# Altium schematic sheet IC.SchDoc
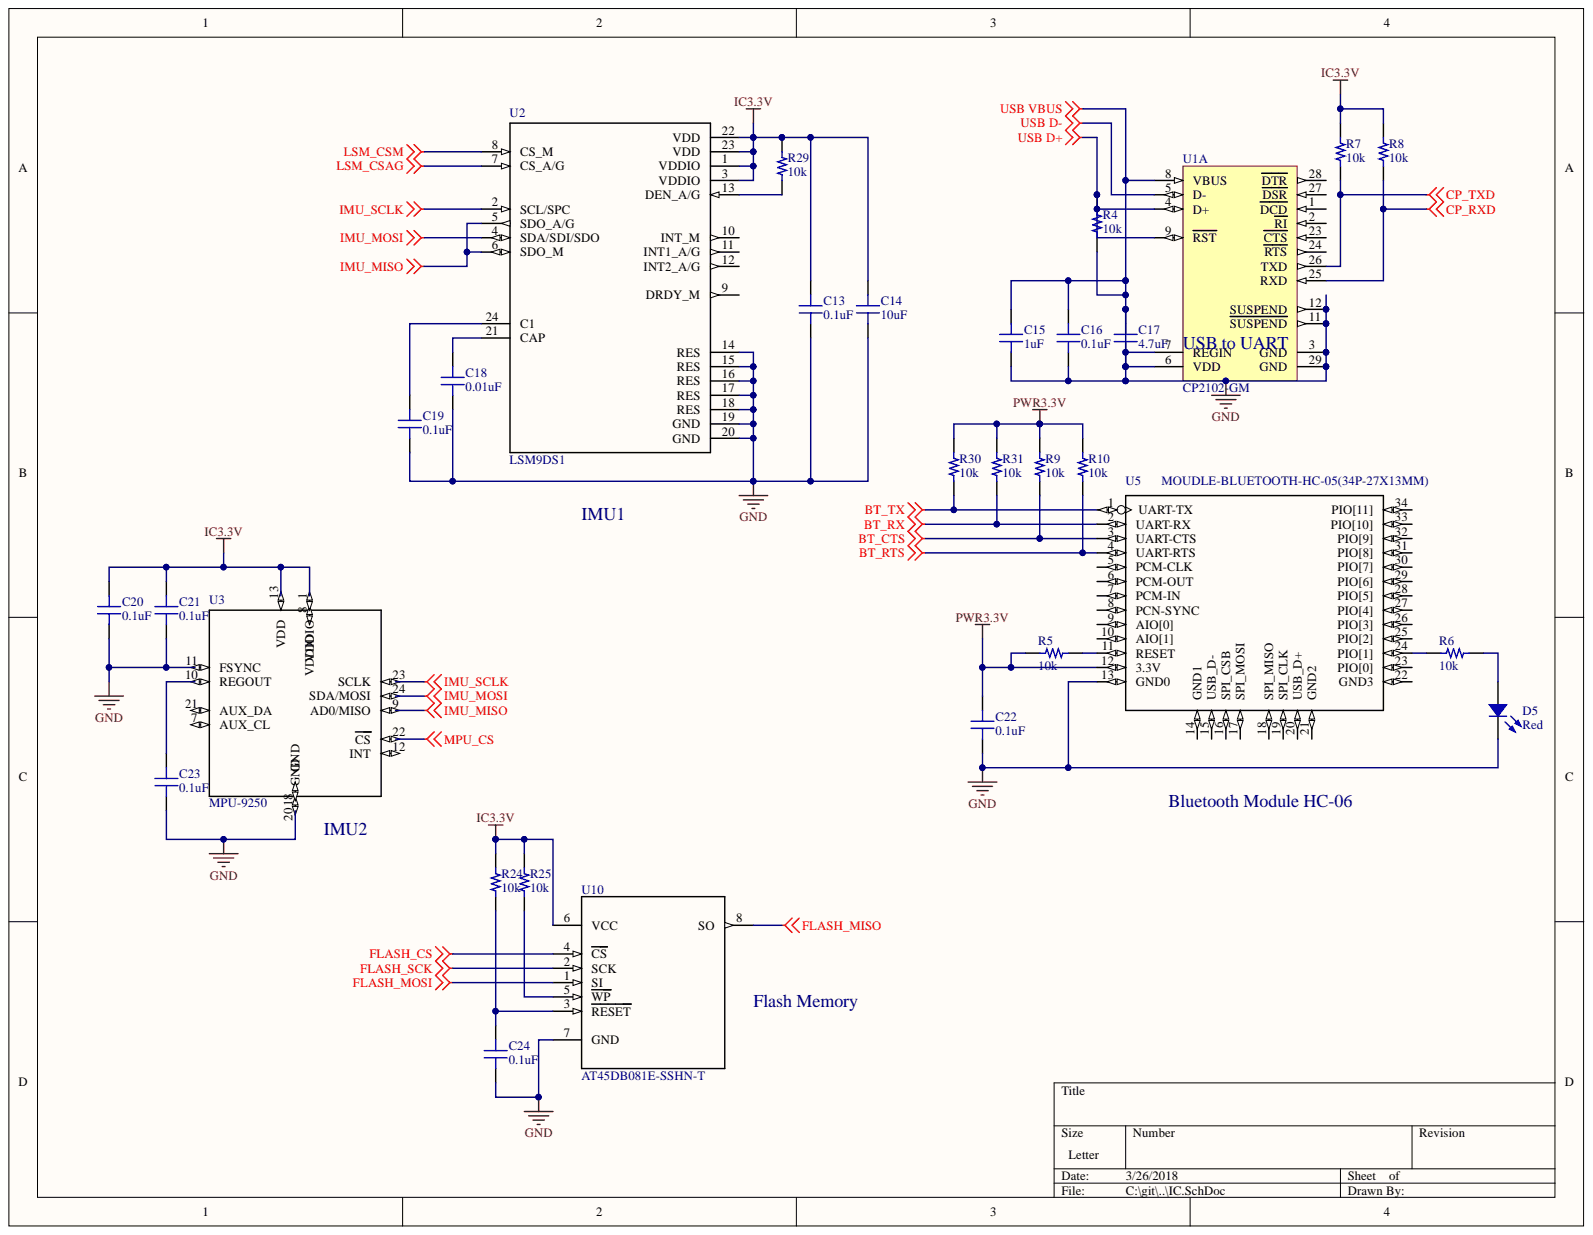
[diagram p0: IC.SchDoc]

## Parts
C13  0.1uF  [CAP 0603/1608]
C14  10uF  [CAP 0603/1608]
C15  1uF  [CAP 0603/1608]
C16  0.1uF  [CAP 0603/1608]
C17  4.7uF  [CAP 0603/1608]
C18  0.01uF  [CAP 0603/1608]
C19  0.1uF  [CAP 0603/1608]
C20  0.1uF  [CAP 0603/1608]
C21  0.1uF  [CAP 0603/1608]
C22  0.1uF  [CAP 0603/1608]
C23  0.1uF  [CAP 0603/1608]
C24  0.1uF  [CAP 0603/1608]
D5  Red  [LED 0603/1608 RED]
R4  10k  [RES 0603/1608]
R5  10k  [RES 0603/1608]
R6  10k  [RES 0603/1608]
R7  10k  [RES 0603/1608]
R8  10k  [RES 0603/1608]
R9  10k  [RES 0603/1608]
R10  10k  [RES 0603/1608]
R24  10k  [RES 0603/1608]
R25  10k  [RES 0603/1608]
R29  10k  [RES 0603/1608]
R30  10k  [RES 0603/1608]
R31  10k  [RES 0603/1608]
U1  CP2102-GM  [SLAB-QFN-28-3125x3125TP_N]  (part A)
U2  LSM9DS1  [PQFN24P43_350X300X102L35X23N]
U3  MPU-9250  [QFN-24/3MM]
U5  MOUDLE-BLUETOOTH-HC-05(34P-27X13MM)  [BT34P-SMD-1.5-26.9X13.0X2.2MM]
U10  AT45DB081E-SSHN-T  [SOIC127P600X175-8N]

## Nets
BT_CTS: R9.1 U5.3(UART-CTS)
BT_RTS: R10.1 U5.4(UART-RTS)
BT_RX: R31.1 U5.2(UART-RX)
BT_TX: R30.1 U5.1(UART-TX)
CP_RXD: R8.1 U1.25(RXD)
CP_TXD: R7.1 U1.26(TXD)
FLASH_CS: U10.4(CS)
FLASH_MISO: U10.8(SO)
FLASH_MOSI: U10.1(SI)
FLASH_SCK: U10.2(SCK)
IC3.3V: C13.1 C14.1 C20.1 C21.1 R7.2 R8.2 R24.2 R25.2 R29.2 U2.1(VDDIO) U2.3(VDDIO) U2.22(VDD) U2.23(VDD) U3.1(VDDIO) U3.13(VDD) U10.6(VCC)
IMU_MISO: U2.5(SDO_A/G) U2.6(SDO_M) U3.9(AD0/MISO)
IMU_MOSI: U2.4(SDA/SDI/SDO) U3.24(SDA/MOSI)
IMU_SCLK: U2.2(SCL/SPC) U3.23(SCLK)
LSM_CSAG: U2.7(CS_A/G)
LSM_CSM: U2.8(CS_M)
MPU_CS: U3.22(CS)
PWR3.3V: C22.1 R5.1 R9.2 R10.2 R30.2 R31.2 U5.12(3.3V)
USB D+: R4.2 U1.4(D+) U1.9(RST)
USB D-: U1.5(D-)
USB VBUS: C13.2 C14.2 C15.1 C15.2 C16.1 C16.2 C17.1 C17.2 C18.2 C19.2 C20.2 C21.2 C22.2 C23.2 C24.2 D5.2 R4.1 U1.3(GND) U1.6(VDD) U1.7(REGIN) U1.8(VBUS) U1.11(SUSPEND) U1.12(SUSPEND) U1.29(GND) U2.14(RES) U2.15(RES) U2.16(RES) U2.17(RES) U2.18(RES) U2.19(GND) U2.20(GND) U3.11(FSYNC) U3.20(GND) U5.13(GND0) U10.7(GND)
NetC18_1: C18.1 U2.21(CAP)
NetC19_1: C19.1 U2.24(C1)
NetC23_1: C23.1 U3.10(REGOUT)
NetC24_1: C24.1 R24.1 U10.3(RESET)
NetD5_1: D5.1 R6.2
NetR5_2: R5.2 U5.11(RESET)
NetR6_1: R6.1 U5.24(PIO[1])
NetR25_1: R25.1 U10.5(WP)
NetR29_1: R29.1 U2.13(DEN_A/G)

Not connected: U1 1(DCD) 2(RI) 23(CTS) 24(RTS) 27(DSR) 28(DTR); U2 9(DRDY_M) 10(INT_M) 11(INT1_A/G) 12(INT2_A/G); U3 7(AUX_CL) 8(VDDIO) 12(INT) 18(GND) 21(AUX_DA); U5 5(PCM-CLK) 6(PCM-OUT) 7(PCM-IN) 8(PCN-SYNC) 9(AIO[0]) 10(AIO[1]) 14(GND1) 15(USB_D-) 16(SPI_CSB) 17(SPI_MOSI) 18(SPI_MISO) 19(SPI_CLK) 20(USB_D+) 21(GND2) 22(GND3) 23(PIO[0]) 25(PIO[2]) 26(PIO[3]) 27(PIO[4]) 28(PIO[5]) 29(PIO[6]) 30(PIO[7]) 31(PIO[8]) 32(PIO[9]) 33(PIO[10]) 34(PIO[11])

## Library data of the parts
C13-C24 = C1608X7R1E104K080AA: CAP CER 0.1UF 25V X7R 0603; Manufacturer: TDK Corporation; Database Table Name: Capacitor - Ceramic; TemperatureRange: -55C ~ 125C; Tolerance: 10%; Component Kind: Standard; ComponentLink1Description: Datasheet; ComponentLink1URL: https://product.tdk.com/info/en/catalog/datasheets/mlcc_commercial_general_en.pdf; ComponentLink2Description: Digi-Key listing; ComponentLink2URL: http://digikey.com/product-detail/en/C1608X7R1E104K080AA/445-1316-1-ND/567697; Device Package: 0603 (1608 Metric); Library Name: Celestial Library Database.DbLib; PartId: 4029; Part Number: C1608X7R1E104K080AA; Price: 0.14; Supplier 1: Digi-Key; Supplier Part Number 1: 445-1316-1-ND; TemperatureCoefficient: X7R; Type: -; Voltage: 25V
  C13 C16 C19-C24: Value: 0.1uF
  C14: Value: 10uF
  C15: Value: 1uF
  C17: Value: 4.7uF
  C18: Value: 0.01uF
D5 = LTST-C191KRKT: LED RED CLEAR 0603 SMD; Manufacturer: Lite-On Inc.; Current (Test): 20mA; Database Table Name: Chip LED; Millicandela: 54mcd; Value: Green; Viewing Angle: 130; Voltage Forward: 2V; Colour: Red; Component Kind: Standard; ComponentLink1Description: Datasheet; ComponentLink1URL: http://optoelectronics.liteon.com/upload/download/DS22-2000-223/S_110_LTST-C191KRKT.pdf; ComponentLink2Description: Digi-Key Listing; ComponentLink2URL: http://www.digikey.ca/product-detail/en/LTST-C191KRKT/160-1447-2-ND/386837; Device Package: 0603 (1608 Metric); Lens Transparency: Clear; Library Name: Celestial Library Database.DbLib; PartId: 7050; Part Number: LTST-C191KRKT; Price: 0.0521; Supplier 1: Digi-Key; Supplier Part Number 1: 160-1447-2-ND
R4-R10 R24 R25 R29-R31 = ERJ-3EKF1002V: RES SMD 10K OHM 1% 1/10W 0603; Manufacturer: Panasonic Electronic Components; Database Table Name: Resistor - Chip; PowerRating: 0.1W, 1/10W; TemperatureCoefficient: 100ppm/C; TemperatureRange: -55C ~ 155C; Component Kind: Standard; ComponentLink1Description: Datasheet; ComponentLink1URL: http://industrial.panasonic.com/www-cgi/jvcr13pz.cgi?E+PZ+3+AOA0002+ERJ3EKF1002V+7+WW; ComponentLink2Description: Digi-Key listing; ComponentLink2URL: http://digikey.com/product-detail/en/ERJ-3EKF1002V/P10.0KHTR-ND/196066; Device Package: 0603 (1608 Metric); Library Name: Celestial Library Database.DbLib; PartId: 886; Part Number: ERJ-3EKF1002V; Price: 0.0038; Supplier 1: Digi-Key; Supplier Part Number 1: P10.0KHTR-ND; Tolerance: 1%; Type: Thick Film; Value: 10k
U1 = CP2102-GM: Single-Chip USB to UART Bridge, 1024 Bytes EEPROM, -40 to 85 degC, 28-pin QFN, Tube; Manufacturer: Silicon Labs; Internal Oscillator: TRUE; Sub-Family: USB Bridges; Code_IPC: J-STD-020C; Code_JEDEC: MO-220; Component Kind: Standard; ComponentLink1Description: Manufacturer URL; ComponentLink1URL: http://www.silabs.com/; ComponentLink2Description: Datasheet; ComponentLink2URL: http://www.silabs.com/Support%20Documents/TechnicalDocs/CP2102-9.pdf; ComponentLink3Description: Package Specification; ComponentLink3URL: http://www.silabs.com/Support%20Documents/TechnicalDocs/C8051T61x.pdf; DatasheetVersion: Rev. 1.5; EEPROM: 1024 Bytes; Library Name: Silicon Labs Interface.IntLib; Mounting Technology: Surface Mount; PackageDescription: 28-Pin Quad-Flat No-Leads Package, Body 5 x 5 mm, Pitch 0.5 mm; PackageReference: QFN-28; PartNumber: CP2102-GM; Supplier 1: Digi-Key; Supplier 2: Farnell; Supplier 3: Newark; Supplier 4: Mouser; Supplier Part Number 1: 336-1160-5-ND; Supplier Part Number 2: 9282130; Supplier Part Number 3: 30K5635; Supplier Part Number 4: 634-CP2102-GM
U2 = LSM9DS1: iNEMO inertial module: 3D accelerometer, 3D gyroscope, 3D magnetometer; MF: STMicroelectronics; Availability: Unavailable; Component Kind: Standard; Library Name: LSM9DS1.IntLib; MP: LSM9DS1; Package: None; Price: None
U3 = MPU-9250: IMU ACCEL/GYRO/MAG I2C/SPI 24QFN; MF: TDK; Availability: Good; Component Kind: Standard; Library Name: MPU-9250.IntLib; MP: MPU-9250; Package: QFN-24 InvenSense; Price: 6.44 USD
U5 = MOUDLE-BLUETOOTH-HC-05(34P-27X13MM): MP: HC-05; Availability: Unavailable; Component Kind: Standard; Library Name: MOUDLE-BLUETOOTH-HC-05_34P-27X13MM_.SchLib; Package: SOIC-14; Price: None
U10 = AT45DB081E-SSHN-T: IC FLASH 8MBIT 85MHZ 8SOIC; MF: Adesto; Availability: Good; Component Kind: Standard; Library Name: AT45DB081E-SSHN-T.IntLib; MP: AT45DB081E-SSHN-T; Package: SOIC-8 Adesto Technologies; Price: 1.21 USD
